# Altium schematic sheet FPGA_DECAPS.SchDoc(FPGA_DECAPS)
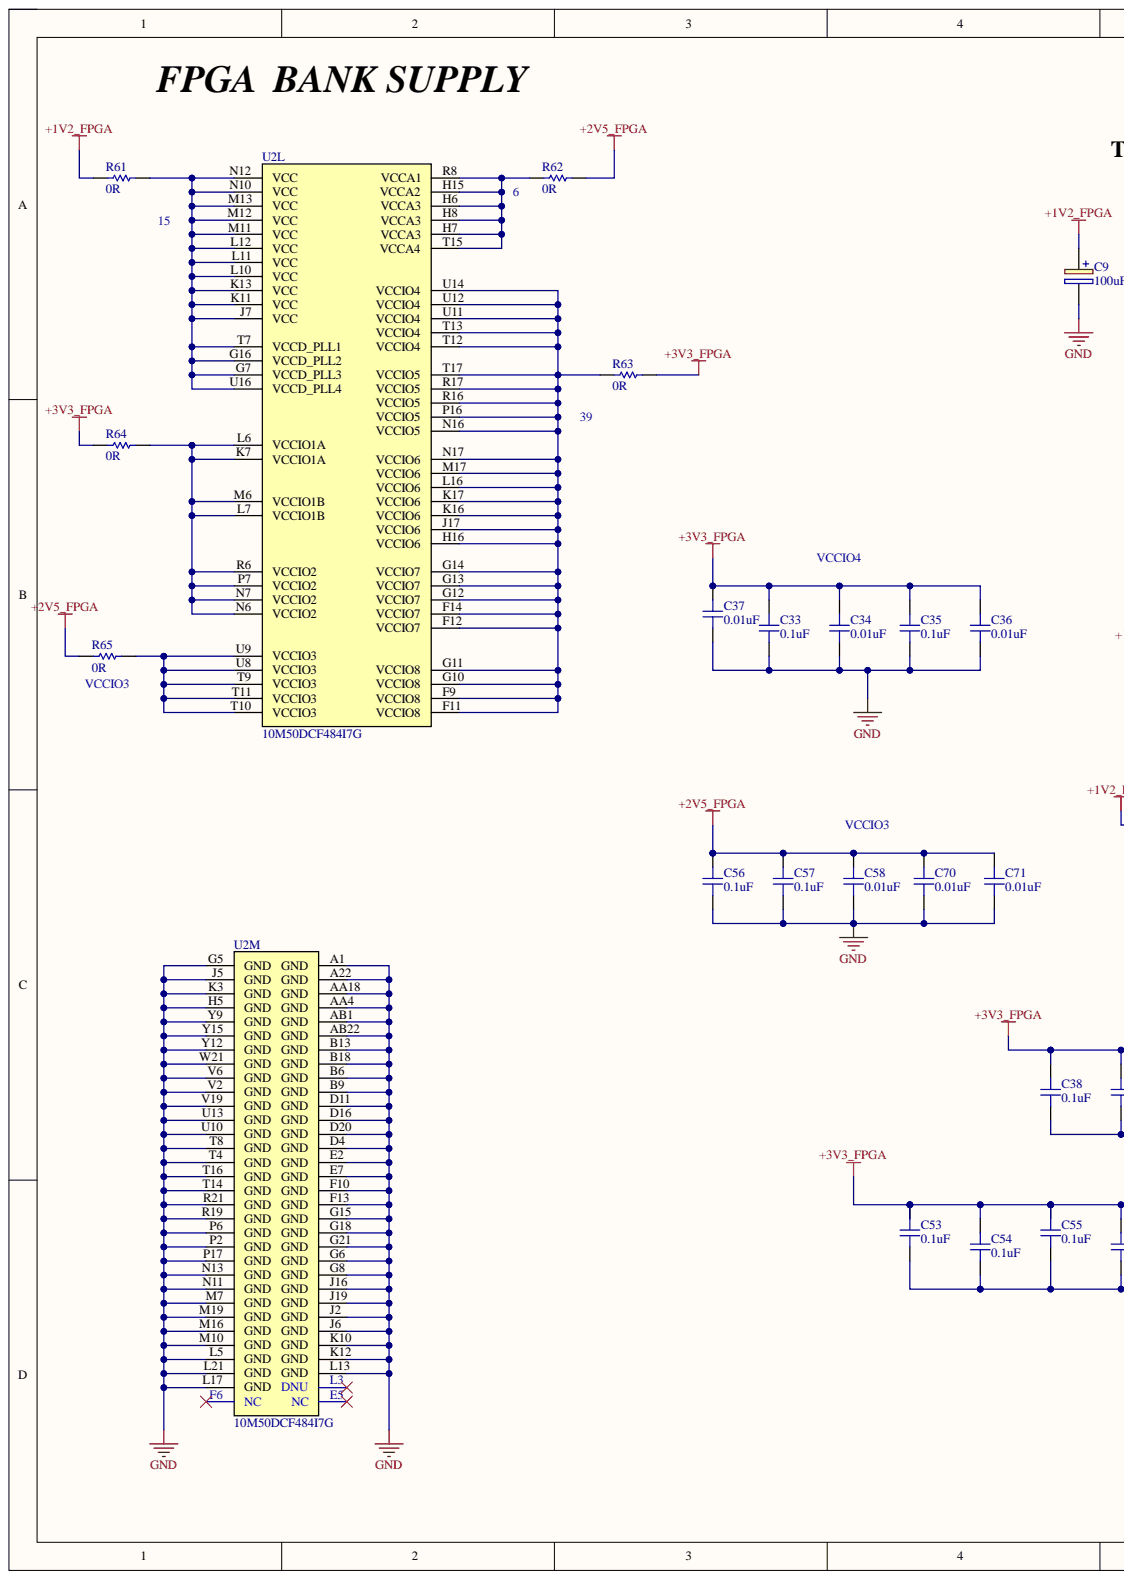
[diagram p0: FPGA_DECAPS.SchDoc, left]
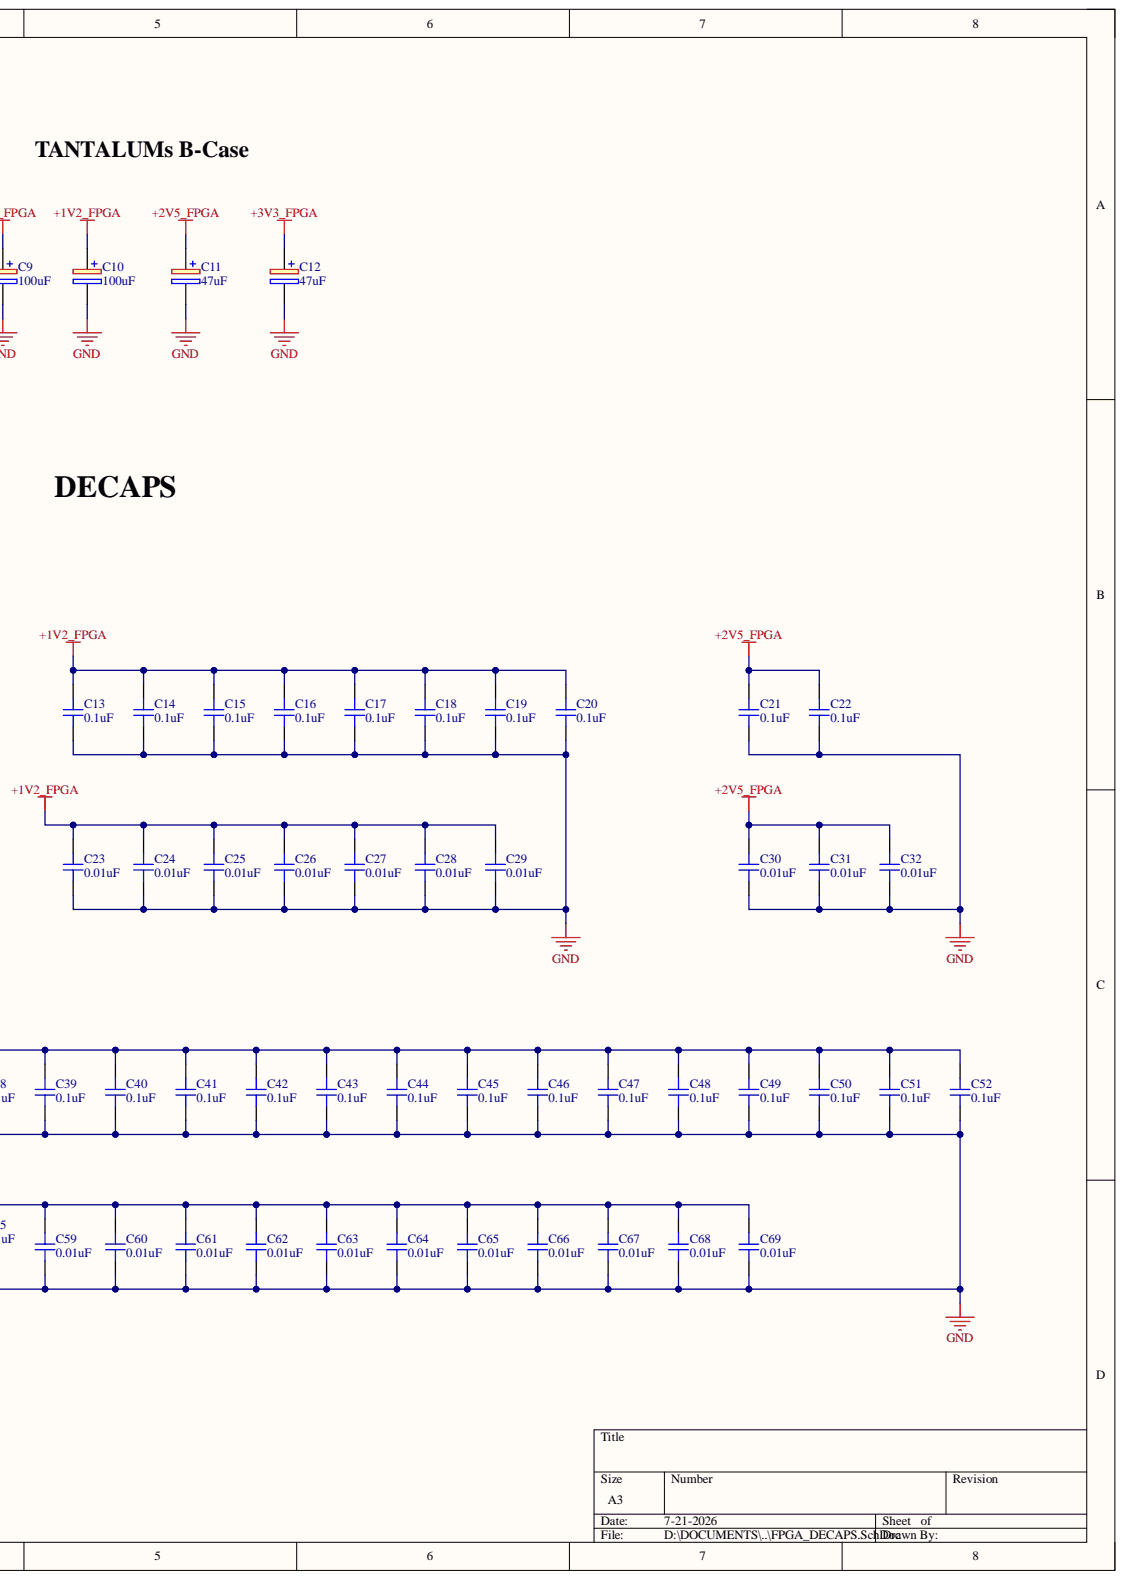
[diagram p1: FPGA_DECAPS.SchDoc, right]

## Parts
C9  100uF  [CAPPM3528X210N]
C10  100uF  [CAPPM3528X210N]
C11  47uF  [CAPPM3528X210N]
C12  47uF  [CAPPM3528X210N]
C13  0.1uF  [CAP_0201]
C14  0.1uF  [CAP_0201]
C15  0.1uF  [CAP_0201]
C16  0.1uF  [CAP_0201]
C17  0.1uF  [CAP_0201]
C18  0.1uF  [CAP_0201]
C19  0.1uF  [CAP_0201]
C20  0.1uF  [CAP_0201]
C21  0.1uF  [CAP_0201]
C22  0.1uF  [CAP_0201]
C23  0.01uF  [CAP_0201]
C24  0.01uF  [CAP_0201]
C25  0.01uF  [CAP_0201]
C26  0.01uF  [CAP_0201]
C27  0.01uF  [CAP_0201]
C28  0.01uF  [CAP_0201]
C29  0.01uF  [CAP_0201]
C30  0.01uF  [CAP_0201]
C31  0.01uF  [CAP_0201]
C32  0.01uF  [CAP_0201]
C33  0.1uF  [CAP_0201]
C34  0.01uF  [CAP_0201]
C35  0.1uF  [CAP_0201]
C36  0.01uF  [CAP_0201]
C37  0.01uF  [CAP_0201]
C38  0.1uF  [CAP_0201]
C39  0.1uF  [CAP_0201]
C40  0.1uF  [CAP_0201]
C41  0.1uF  [CAP_0201]
C42  0.1uF  [CAP_0201]
C43  0.1uF  [CAP_0201]
C44  0.1uF  [CAP_0201]
C45  0.1uF  [CAP_0201]
C46  0.1uF  [CAP_0201]
C47  0.1uF  [CAP_0201]
C48  0.1uF  [CAP_0201]
C49  0.1uF  [CAP_0201]
C50  0.1uF  [CAP_0201]
C51  0.1uF  [CAP_0201]
C52  0.1uF  [CAP_0201]
C53  0.1uF  [CAP_0201]
C54  0.1uF  [CAP_0201]
C55  0.1uF  [CAP_0201]
C56  0.1uF  [CAP_0201]
C57  0.1uF  [CAP_0201]
C58  0.01uF  [CAP_0201]
C59  0.01uF  [CAP_0201]
C60  0.01uF  [CAP_0201]
C61  0.01uF  [CAP_0201]
C62  0.01uF  [CAP_0201]
C63  0.01uF  [CAP_0201]
C64  0.01uF  [CAP_0201]
C65  0.01uF  [CAP_0201]
C66  0.01uF  [CAP_0201]
C67  0.01uF  [CAP_0201]
C68  0.01uF  [CAP_0201]
C69  0.01uF  [CAP_0201]
C70  0.01uF  [CAP_0201]
C71  0.01uF  [CAP_0201]
R61  0R  [RES_0402]
R62  0R  [RES_0402]
R63  0R  [RES_0402]
R64  0R  [RES_0402]
R65  0R  [RES_0402]
U2  10M50DCF484I7G  [BGA484C100P22X22_2300X2300X200]  (parts L,M)

## Nets
+1V2_FPGA: C9.1 C10.1 C13.1 C14.1 C15.1 C16.1 C17.1 C18.1 C19.1 C20.1 C23.2 C24.2 C25.2 C26.2 C27.2 C28.2 C29.2 R61.1
+2V5_FPGA: C11.1 C21.1 C22.1 C30.2 C31.2 C32.2 C56.1 C57.1 C58.2 C70.2 C71.2 R62.2 R65.1
+3V3_FPGA: C12.1 C33.1 C34.1 C35.1 C36.1 C37.1 C38.1 C39.1 C40.1 C41.1 C42.1 C43.1 C44.1 C45.1 C46.1 C47.1 C48.1 C49.1 C50.1 C51.1 C52.1 C53.1 C54.1 C55.1 C59.2 C60.2 C61.2 C62.2 C63.2 C64.2 C65.2 C66.2 C67.2 C68.2 C69.2 R63.2 R64.1
GND: C9.2 C10.2 C11.2 C12.2 C13.2 C14.2 C15.2 C16.2 C17.2 C18.2 C19.2 C20.2 C21.2 C22.2 C23.1 C24.1 C25.1 C26.1 C27.1 C28.1 C29.1 C30.1 C31.1 C32.1 C33.2 C34.2 C35.2 C36.2 C37.2 C38.2 C39.2 C40.2 C41.2 C42.2 C43.2 C44.2 C45.2 C46.2 C47.2 C48.2 C49.2 C50.2 C51.2 C52.2 C53.2 C54.2 C55.2 C56.2 C57.2 C58.1 C59.1 C60.1 C61.1 C62.1 C63.1 C64.1 C65.1 C66.1 C67.1 C68.1 C69.1 C70.1 C71.1 U2.A1(GND) U2.A22(GND) U2.AA4(GND) U2.AA18(GND) U2.AB1(GND) U2.AB22(GND) U2.B6(GND) U2.B9(GND) U2.B13(GND) U2.B18(GND) U2.D4(GND) U2.D11(GND) U2.D16(GND) U2.D20(GND) U2.E2(GND) U2.E7(GND) U2.F10(GND) U2.F13(GND) U2.G5(GND) U2.G6(GND) U2.G8(GND) U2.G15(GND) U2.G18(GND) U2.G21(GND) U2.H5(GND) U2.J2(GND) U2.J5(GND) U2.J6(GND) U2.J16(GND) U2.J19(GND) U2.K3(GND) U2.K10(GND) U2.K12(GND) U2.L5(GND) U2.L13(GND) U2.L17(GND) U2.L21(GND) U2.M7(GND) U2.M10(GND) U2.M16(GND) U2.M19(GND) U2.N11(GND) U2.N13(GND) U2.P2(GND) U2.P6(GND) U2.P17(GND) U2.R19(GND) U2.R21(GND) U2.T4(GND) U2.T8(GND) U2.T14(GND) U2.T16(GND) U2.U10(GND) U2.U13(GND) U2.V2(GND) U2.V6(GND) U2.V19(GND) U2.W21(GND) U2.Y9(GND) U2.Y12(GND) U2.Y15(GND)
NetR61_2: R61.2 U2.G7(VCCD_PLL3) U2.G16(VCCD_PLL2) U2.J7(VCC) U2.K11(VCC) U2.K13(VCC) U2.L10(VCC) U2.L11(VCC) U2.L12(VCC) U2.M11(VCC) U2.M12(VCC) U2.M13(VCC) U2.N10(VCC) U2.N12(VCC) U2.T7(VCCD_PLL1) U2.U16(VCCD_PLL4)
NetR62_1: R62.1 U2.H6(VCCA3) U2.H7(VCCA3) U2.H8(VCCA3) U2.H15(VCCA2) U2.R8(VCCA1) U2.T15(VCCA4)
NetR63_1: R63.1 U2.F9(VCCIO8) U2.F11(VCCIO8) U2.F12(VCCIO7) U2.F14(VCCIO7) U2.G10(VCCIO8) U2.G11(VCCIO8) U2.G12(VCCIO7) U2.G13(VCCIO7) U2.G14(VCCIO7) U2.H16(VCCIO6) U2.J17(VCCIO6) U2.K16(VCCIO6) U2.K17(VCCIO6) U2.L16(VCCIO6) U2.M17(VCCIO6) U2.N16(VCCIO5) U2.N17(VCCIO6) U2.P16(VCCIO5) U2.R16(VCCIO5) U2.R17(VCCIO5) U2.T12(VCCIO4) U2.T13(VCCIO4) U2.T17(VCCIO5) U2.U11(VCCIO4) U2.U12(VCCIO4) U2.U14(VCCIO4)
NetR64_2: R64.2 U2.K7(VCCIO1A) U2.L6(VCCIO1A) U2.L7(VCCIO1B) U2.M6(VCCIO1B) U2.N6(VCCIO2) U2.N7(VCCIO2) U2.P7(VCCIO2) U2.R6(VCCIO2)
NetR65_2: R65.2 U2.T9(VCCIO3) U2.T10(VCCIO3) U2.T11(VCCIO3) U2.U8(VCCIO3) U2.U9(VCCIO3)

Not connected: U2 E5(NC) F6(NC) L3(DNU)

## Library data of the parts
C9 C10 = CAP_1411: Tantalum; MPN: TAJB107M010RNJ; Case: B; Component Kind: Standard; Height: 2.1mm; Library Name: FPGA_LIB.SchLib
C11 C12 = CAP_1411: Tantalum; MPN: 293D476X0010B2TE3; Case: B; Component Kind: Standard; Height: 2.1mm; Library Name: FPGA_LIB.SchLib
C13-C22 C33-C57 = CAP_0201_16V: C0201_16V; MPN: GRM033Z71C104KE14D; Component Kind: Standard; Library Name: FPGA_LIB.SchLib
C23-C32 C58-C71 = CAP_0201_25V: C0201_25V; MPN: UMK063BJ103MP-F; Component Kind: Standard; Library Name: FPGA_LIB.SchLib
R61-R65 = RES_0402: R0402; Component Kind: Standard; Library Name: ANAND_LIB.SchLib
U2 = 10M50DCF484I7G: 360 IO FPGA; Manufacturer_Name: Intel; Pitch: 1mm; Component Kind: Standard; Datasheet Link: https://componentsearchengine.com/Datasheets/1/10M40DCF484C8G.pdf; Height: 2mm; Library Name: FPGA_LIB.SchLib; MPN: 10M50DCF484I7G
